# Altium schematic sheet Sensor-Sheet2.SchDoc(Sensor-Sheet2)
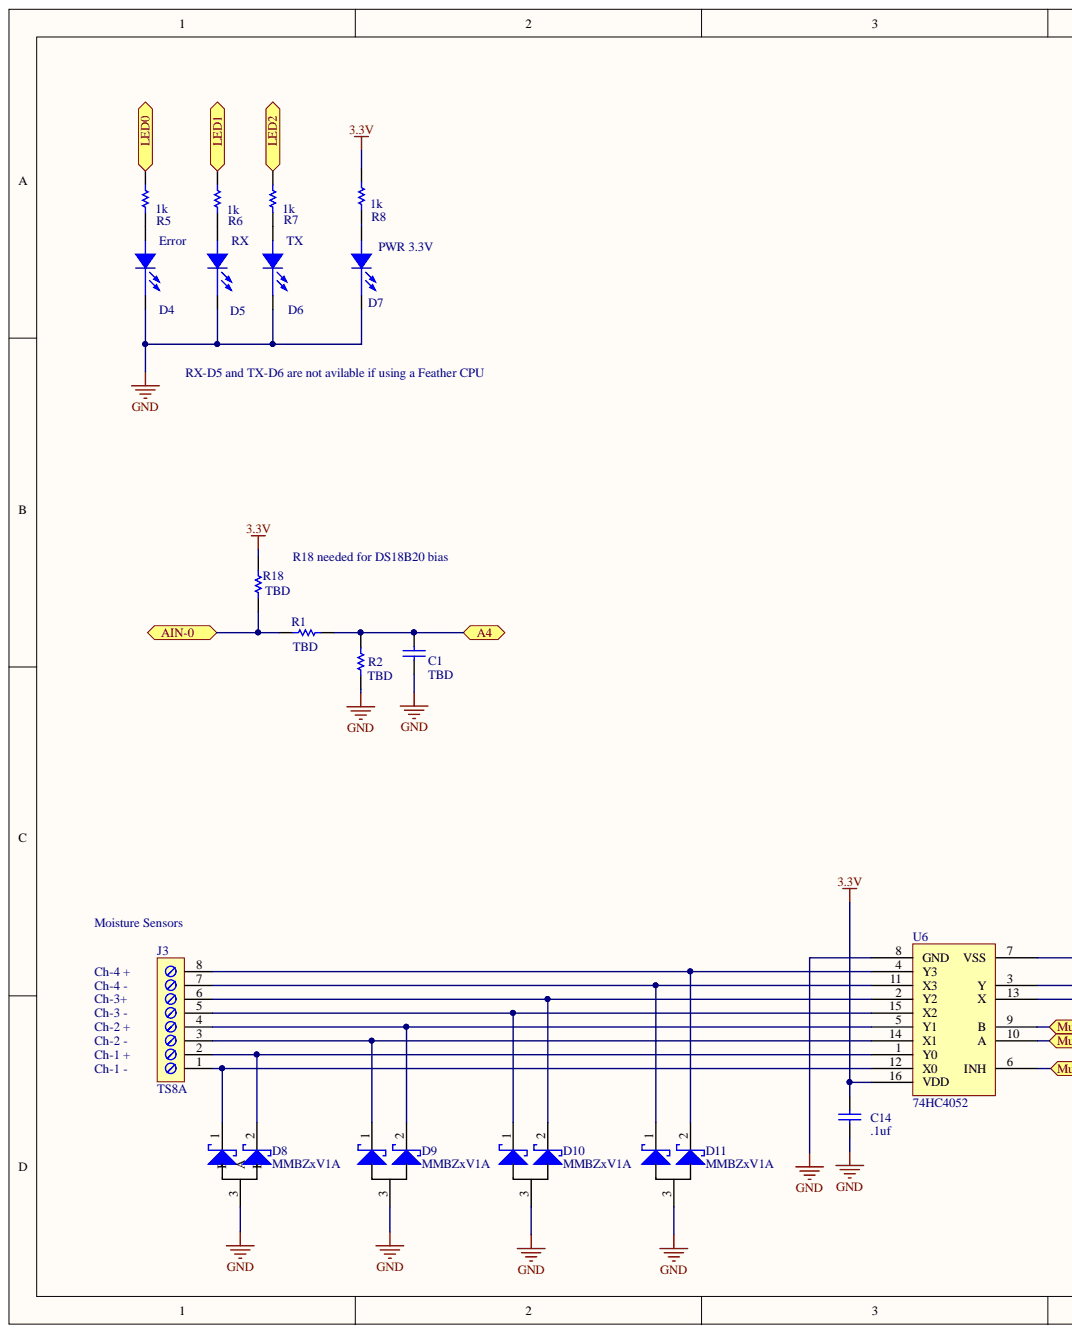
[diagram p0: Sensor-Sheet2.SchDoc, left]
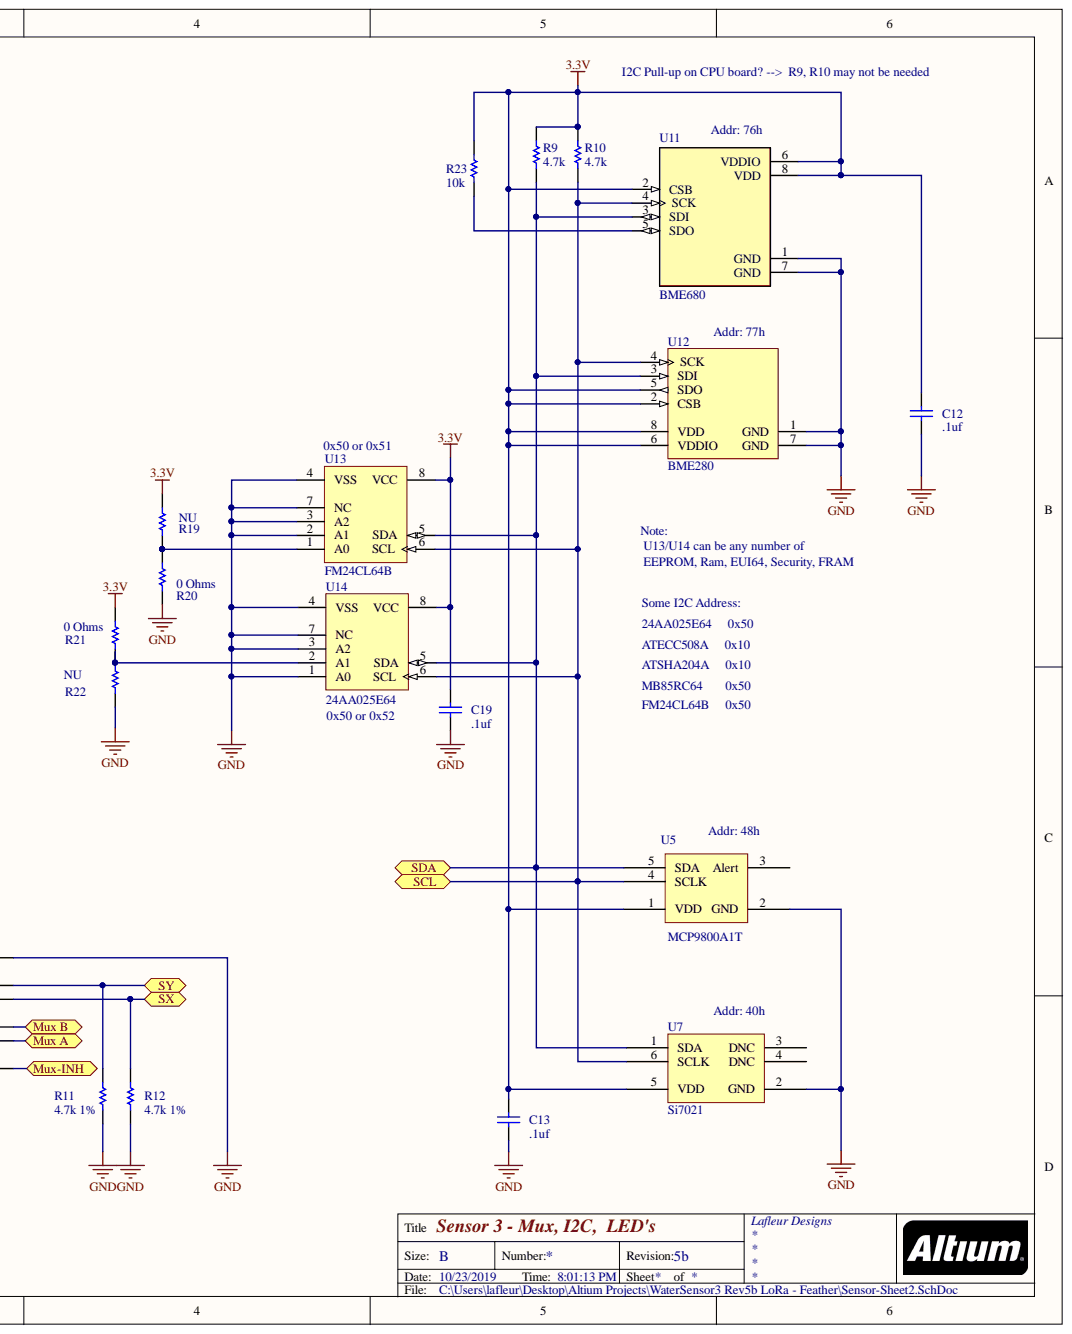
[diagram p1: Sensor-Sheet2.SchDoc, right]

## Parts
C1  TBD  [0805]
C12  .1uf  [0805]
C13  .1uf  [0805]
C14  .1uf  [0805]
C19  .1uf  [0805]
D4  Error  [LED 0805]
D5  RX  [LED 0805]
D6  TX  [LED 0805]
D7  PWR 3.3V  [LED 0805]
D8  MMBZxV1A  [SOT23]
D9  MMBZxV1A  [SOT23]
D10  MMBZxV1A  [SOT23]
D11  MMBZxV1A  [SOT23]
J3  TS8A  [TS3.81X8]
R1  TBD  [6-0805]
R2  TBD  [6-0805]
R5  1k  [6-0805]
R6  1k  [6-0805]
R7  1k  [6-0805]
R8  1k  [6-0805]
R9  4.7k  [6-0805]
R10  4.7k  [6-0805]
R11  4.7k 1%  [6-0805]
R12  4.7k 1%  [6-0805]
R18  TBD  [6-0805]
R19  NU  [6-0805]
R20  0 Ohms  [6-0805]
R21  0 Ohms  [6-0805]
R22  NU  [6-0805]
R23  10k  [6-0805]
U5  MCP9800A1T  [SOT-23-OT5_L]
U6  74HC4052  [SOP127P1030X265-16L]
U7  Si7021  [QFN3x3-6pin]
U11  BME680  [BME680-EXT-Pad]
U12  BME280  [BOSC-BME280-8_V]
U13  FM24CL64B  [MCHP-SOIC-SN8_N]
U14  24AA025E64  [MCHP-SOIC-SN8_N]

## Ports
A4, AIN-0, LED0, LED1, LED2, Mux A, Mux B, Mux-INH, SCL, SDA, SX, SY

## Nets
3.3V: C12.1 C13.1 C14.1 C19.1 R8.2 R9.2 R10.2 R18.2 R19.2 R21.2 R23.2 U5.1(VDD) U6.16(VDD) U7.5(VDD) U11.2(CSB) U11.6(VDDIO) U11.8(VDD) U12.2(CSB) U12.5(SDO) U12.6(VDDIO) U12.8(VDD) U13.8(VCC) U14.8(VCC)
GND: C1.2 C12.2 C13.2 C14.2 C19.2 D4.2 D5.2 D6.2 D7.2 D8.3 D9.3 D10.3 D11.3 R2.1 R11.1 R12.1 R20.1 R22.1 U5.2(GND) U6.7(VSS) U6.8(GND) U7.2(GND) U11.1(GND) U11.7(GND) U12.1(GND) U12.7(GND) U13.2(A1) U13.3(A2) U13.4(VSS) U13.7(NC) U14.1(A0) U14.3(A2) U14.4(VSS) U14.7(NC)
A4: C1.1 R1.2 R2.2 ; port A4
AIN-0: R1.1 R18.1 ; port AIN-0
LED0: R5.2 ; port LED0
LED1: R6.2 ; port LED1
LED2: R7.2 ; port LED2
Mux A: U6.10(A) ; port Mux A
Mux B: U6.9(B) ; port Mux B
Mux-INH: U6.6(INH) ; port Mux-INH
SCL: R10.1 U5.4(SCLK) U7.6(SCLK) U11.4(SCK) U12.4(SCK) U13.6(SCL) U14.6(SCL) ; port SCL
SDA: R9.1 U5.5(SDA) U7.1(SDA) U11.3(SDI) U12.3(SDI) U13.5(SDA) U14.5(SDA) ; port SDA
SX: R12.2 U6.13(X) ; port SX
SY: R11.2 U6.3(Y) ; port SY
NetD4_1: D4.1 R5.1
NetD5_1: D5.1 R6.1
NetD6_1: D6.1 R7.1
NetD7_1: D7.1 R8.1
NetD8_1: D8.1 J3.1 U6.12(X0)
NetD8_2: D8.2 J3.2 U6.1(Y0)
NetD9_1: D9.1 J3.3 U6.14(X1)
NetD9_2: D9.2 J3.4 U6.5(Y1)
NetD10_1: D10.1 J3.5 U6.15(X2)
NetD10_2: D10.2 J3.6 U6.2(Y2)
NetD11_1: D11.1 J3.7 U6.11(X3)
NetD11_2: D11.2 J3.8 U6.4(Y3)
NetR19_1: R19.1 R20.2 U13.1(A0)
NetR21_1: R21.1 R22.2 U14.2(A1)
NetR23_1: R23.1 U11.5(SDO)
NetU5_3: U5.3(Alert)
NetU7_3: U7.3(DNC)
NetU7_4: U7.4(DNC)

## Library data of the parts
C1 C12-C14 C19 = CAP_1: Capacitor; Fitted: True; Library Name: N2K Project.SCHLIB; Component Kind: Standard
D4-D7 = LTST150: Vendor Part Number: LTST-C150GKRT; Part Description: SMT LED Green; Vendor: LITEON; Flex_PN: D20000; DEVICE: C150; Component Kind: Standard; Library Name: Winmor-Demo-R1.IntLib
D8-D11 = MMBZ9V1A: Zener Transient Voltage Suppressors; Library Name: WaterSensor_5.SCHLIB; Component Kind: Standard
J3 = TS8A: Screw Terminal 3.81mm (.15in) 8X; Component Kind: Standard; Library Name: Winmor-Demo-R1.IntLib
R1 R2 R5-R12 R18-R23 = ERJ8EN: Precision Thick Film Chip Resistor, 10 Ohm to 2.2M Ohm Range, 1% Tolerance, 1206 Size, 0.125 W; Published: 17-Dec-2003; PackageReference: 8-1206; PackageDocument: Mar-2003; LatestRevisionNote: Silkscreen size reduced.; LatestRevisionDate: 19-Mar-2004; ComponentLink2URL: http://www.panasonic.com/industrial/components/pdf/AOA0000CE2.pdf; ComponentLink2Description: Datasheet; ComponentLink1URL: http://www.panasonic.com/industrial/components/resistive_products.htm; ComponentLink1Description: Manufacturer Link; Component Kind: Standard; Code_IPC: 3216 [1206]; Publisher: Altium Limited
  R1 R2 R9-R12 R18: Simulation: RESISTOR; Library Name: N2K Project.SCHLIB
  R5-R8 R19-R23: Library Name: Winmor-Demo-R1.IntLib
U5 = MCP9800A1T: Temperature Sensor, I2C; Library Name: WaterSensor_3.SCHLIB; Component Kind: Standard
U6 = 74HC4052: Dual 4-channel aAalog Mux; Library Name: WaterSensor_5.SCHLIB; Component Kind: Standard
U7 = Si7021: Humidity & Temperature Sensor, I2C,  3x3mm; Library Name: WaterSensor_3.SCHLIB; Component Kind: Standard
U11 = BME680: Integrated Environmental Unit; MF: Bosch; SnapEDA_Link: https://www.snapeda.com/parts/BME680/Bosch%20Sensortec/view-part/?ref=snap; Package: LGA-8 Bosch Tools; MP: BME680; Library Name: BME680.SchLib; Digi-Key_Purchase_URL: https://www.digikey.com/product-detail/en/bosch-sensortec/BME680/828-1077-1-ND/7401321?utm_source=snapeda&utm_medium=aggregator&utm_campaign=symbol; Digi-Key_Part_Number: 828-1077-1-ND; Component Kind: Standard
U12 = CMP-2000-05073-1: Combined Humidity and Pressure Sensor, 1.71 to 3.6 V, -40 to 85 degC, 8-Pin SMD, RoHS, Tape and Reel; Manufacturer: Bosch Sensortec; Manufacturer URL: http://www.bosch-sensortec.com; Library Name: Altium Content Vault; Datasheet Version: Rev. 1.2, 10/2015; Datasheet URL: http://datasheet.octopart.com/BME280-Bosch-datasheet-58577763.pdf; Component Kind: Standard
U13 U14 = FM24CL64B: 2K I2C Serial EEPROMs with EUI-64 Node Identity, 1.7 to 5.5 V, 400 KHz, Industrial Temperature, 8-Pin SOIC (SN8), Tape and Reel; PartNumber: 24AA02E64T-I/SN; Manufacturer: Microchip; VCC Range: 1.7 to 5.5 V; Packing: Tape and Reel; PackageVersion: C04-057C, 12/2012; PackageReference: SOIC-SN8; PackageDescription: 8-Lead Plastic Small Outline, 4.9 x 3.9 mm Body, 1.27 mm Pitch; Mounting Technology: Surface Mount; Manufacturer Part Number: 24AA02E64T-I/SN; Library Name: WaterSensor 2.SCHLIB; DatasheetVersion: Rev. E; ComponentLink3URL: http://ww1.microchip.com/downloads/en/DeviceDoc/20002122B.pdf; ComponentLink3Description: Package Specification; ComponentLink2URL: http://ww1.microchip.com/downloads/en/DeviceDoc/20002124E.pdf; ComponentLink2Description: Datasheet; ComponentLink1URL: http://www.microchip.com/; ComponentLink1Description: Manufacturer URL; Component Kind: Standard
